# Altium schematic sheet 3_CAN.SchDoc(U_3_CAN)
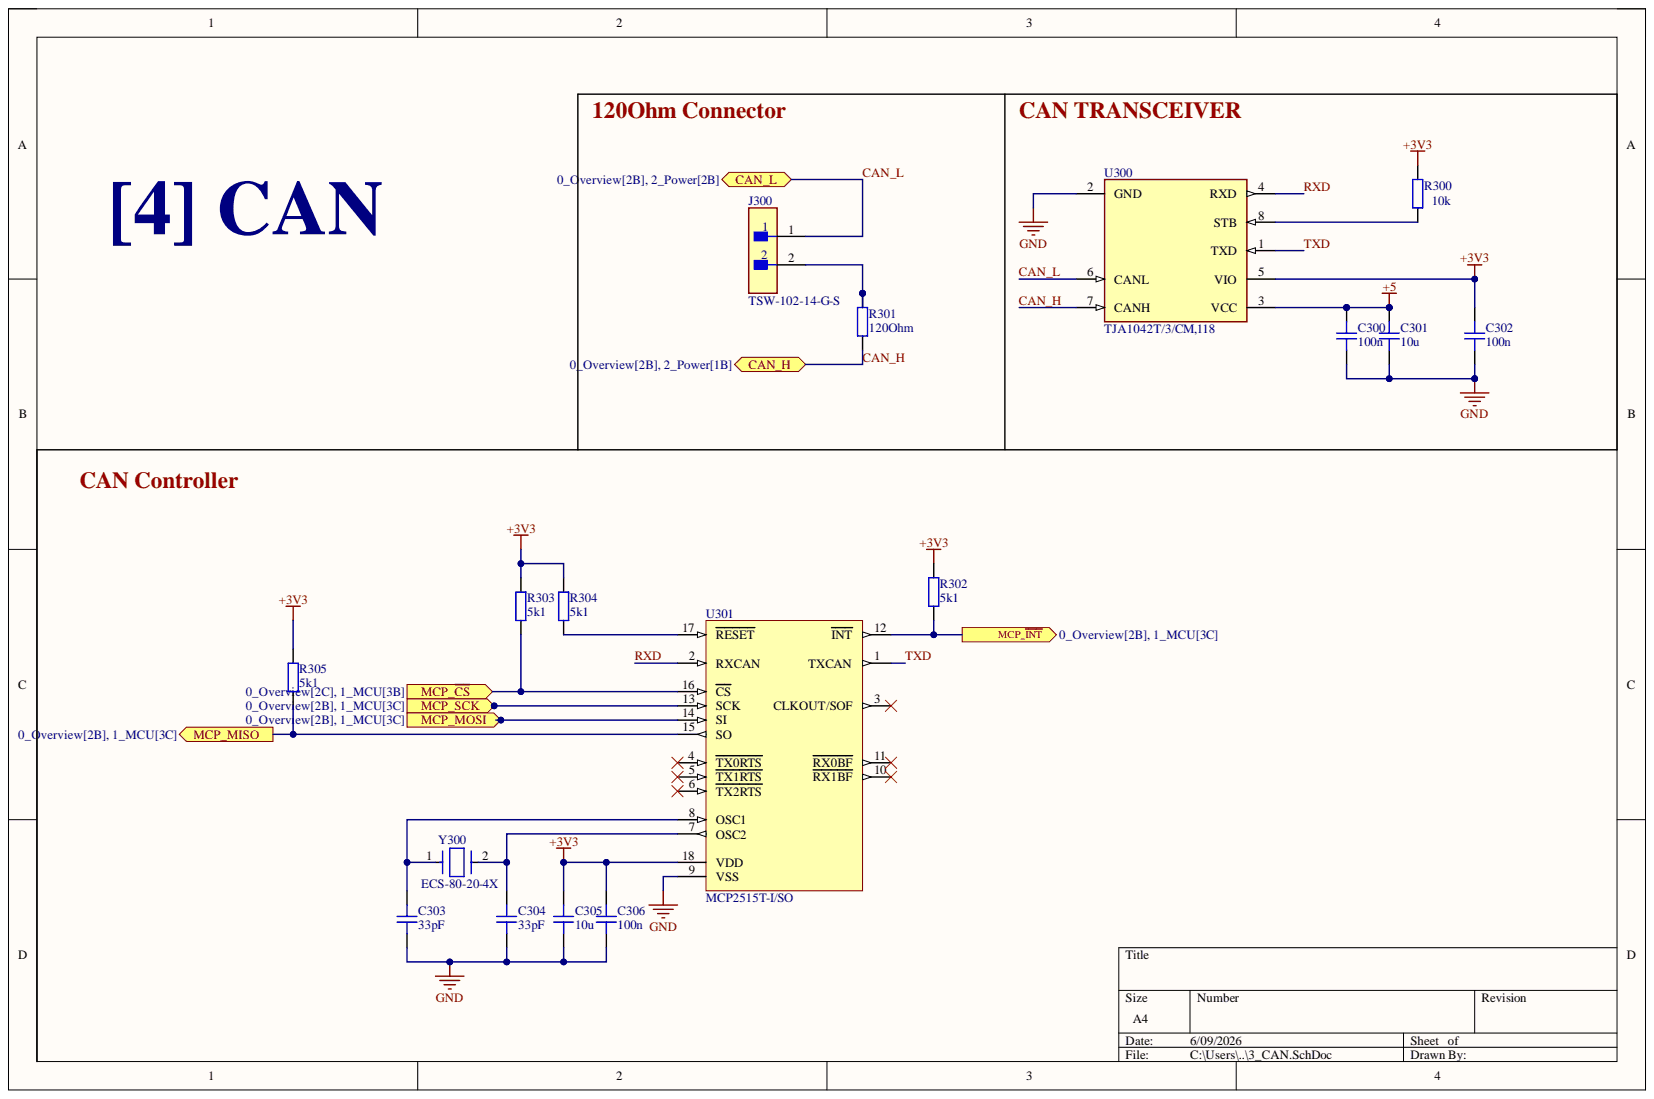
[diagram p0: 3_CAN.SchDoc]

## Parts
C300  100n  [CAP_0603]
C301  10u  [CAP_0805]
C302  100n  [CAP_0603]
C303  33pF  [CAP_0603]
C304  33pF  [CAP_0603]
C305  10u  [CAP_0805]
C306  100n  [CAP_0603]
J300  TSW-102-14-G-S  [FP-TSW-102-14-G-S-MFG]
R300  10k  [RES_0603]
R301  120Ohm  [RES_0603]
R302  5k1  [RES_0603]
R303  5k1  [RES_0603]
R304  5k1  [RES_0603]
R305  5k1  [RES_0603]
U300  TJA1042T/3/CM,118  [FP-SOT96-1-IPC_A]
U301  MCP2515T-I/SO  [SOIC-SO18_N]
Y300  ECS-80-20-4X  [FP-ECS-80-20-4X-MFG]

## Ports
CAN_H, CAN_L, MCP_MISO, MCP_MOSI, MCP_SCK, MCP_~{CS}, MCP_~{INT}

## Nets
+3V3: C302.1 C305.2 C306.1 R300.2 R302.2 R303.2 R304.2 R305.2 U300.5(VIO) U301.18(VDD)
+5: C300.1 C301.2 U300.3(VCC)
GND: C300.2 C301.1 C302.2 C303.1 C304.1 C305.1 C306.2 U300.2(GND) U301.9(VSS)
CAN_H: R301.1 U300.7(CANH) ; port CAN_H
CAN_L: J300.1 U300.6(CANL) ; port CAN_L
MCP_~{CS}: R303.1 U301.16(CS) ; port MCP_~{CS}
MCP_~{INT}: R302.1 U301.12(INT) ; port MCP_~{INT}
MCP_MISO: R305.1 U301.15(SO) ; port MCP_MISO
MCP_MOSI: U301.14(SI) ; port MCP_MOSI
MCP_SCK: U301.13(SCK) ; port MCP_SCK
RXD: U300.4(RXD) U301.2(RXCAN)
TXD: U300.1(TXD) U301.1(TXCAN)
NetC303_2: C303.2 U301.8(OSC1) Y300.1
NetC304_2: C304.2 U301.7(OSC2) Y300.2
NetJ300_2: J300.2 R301.2
NetR300_1: R300.1 U300.8(STB)
NetR304_1: R304.1 U301.17(RESET)
NetU301_3: U301.3(CLKOUT/SOF)
NetU301_4: U301.4(TX0RTS)
NetU301_5: U301.5(TX1RTS)
NetU301_6: U301.6(TX2RTS)
NetU301_10: U301.10(RX1BF)
NetU301_11: U301.11(RX0BF)

## Library data of the parts
C300 C302-C304 C306 = CAP_0603: Capacitor SMD 0603; Component Kind: Standard; Library Name: Schematic-Lib-PhilsLab.SchLib
C301 C305 = CAP_0805: Capacitor SMD 0805; Component Kind: Standard; Library Name: Schematic-Lib-PhilsLab.SchLib
J300 = CMP-02766-001740-1: CONN HEADER VERT 2POS 2.54MM; Gender: Male; Max Current Rating: 7.6A; Max Voltage Rating (AC): 550V; Number of Contacts: 2; Color: Black; Component Kind: Standard; Connector Type: Header; Contact Finish - Mating: GOLD; Contact Material: Bronze; Contact Mating Length: 0.32inch; Contact Plating: Gold; Contact Shape: Square; Contact Type: Male Pin; Depth: 2.54mm; Fastening Type: Pull; Flammability Rating: UL94 V-0; Housing Color: Black; Housing Material: Polyester; Insulation Color: Black; Insulation Material: PBT; Lead Free: Lead Free; Length: 5.08mm; Library Name: Altium Content Vault; Max Operating Temperature: 125°C; Min Operating Temperature: -55°C; Mount: Through Hole; Number of Positions: 2; Number of Rows: 1; Orientation: Straight; Package Quantity: 1; Packaging: Bulk; Pitch: 2.54mm; Radiation Hardening: No; REACH SVHC: No SVHC; RoHS Compliant: Yes; Series: TSW; Termination: Solder; Width: 2.54mm
R300-R305 = RES_0603: Resistor SMD 0603; Component Kind: Standard; Library Name: Schematic-Lib-PhilsLab.SchLib
U300 = CMP-10098-000021-1: IC TRANSCEIVER HALF 1/1 8SO; Data Rate: 1Mbps; Max Supply Current: 70mA; Max Supply Voltage: 5.5V; Min Supply Voltage: 4.5V; Number of Transceivers: 1; Operating Supply Voltage: 5V; Case/Package: SO; Component Kind: Standard; Contact Plating: Gold; Lead Free: Lead Free; Library Name: Altium Content Vault; Max Operating Temperature: 150°C; Min Operating Temperature: -40°C; Mount: Surface Mount; Nominal Supply Current: 70mA; Number of Pins: 8; Package Quantity: 2500; Packaging: Tape and Reel; Radiation Hardening: No
U301 = CMP-0186-00139-2: Stand-Alone CAN Controller With SPI Interface, 18-Pin SOIC, Industrial Temperature, Tape and Reel; Code_JEDEC: MS-013; Component Kind: Standard; ComponentLink1Description: Manufacturer URL; ComponentLink1URL: http://www.microchip.com/; ComponentLink2Description: Datasheet; ComponentLink2URL: http://ww1.microchip.com/downloads/en/DeviceDoc/21801f.pdf; ComponentLink3Description: Package Specification; ComponentLink3URL: http://www.microchip.com/stellent/groups/techpub_sg/documents/packagingspec/en012702.pdf; DatasheetVersion: revF, Oct-2010; Library Name: Altium Content Vault; PackageDescription: 18-Lead Plastic Small Outline (SO) - Wide 7.50mm Body [SOIC]; PackageReference: SOIC-SO18; PackageVersion: revBB, Aug-2009; RevisionNote: URLs added. DatasheetVersion updated.
Y300 = CMP-1669-00002-3: NexarPartId: 316504; ESR (Equivalent Series Resistance): 80Ω; Frequency: 8MHz; Frequency Stability: 50ppm; Frequency Tolerance: 0.003%; Load Capacitance: 20pF; Component Kind: Standard; Height: 3.5mm; Lead Free: Lead Free; Length: 11.3538mm; Library Name: Altium Content Vault; Max Operating Temperature: 70°C; Min Operating Temperature: -10°C; Mount: Through Hole; Number of Pins: 49; Packaging: Bulk; RoHS: Compliant; Schedule B: 8541600025, 8541600025; Termination: Radial; Tolerance: 0.003%; Width: 4.65mm
